# Altium schematic sheet MCU.SchDoc
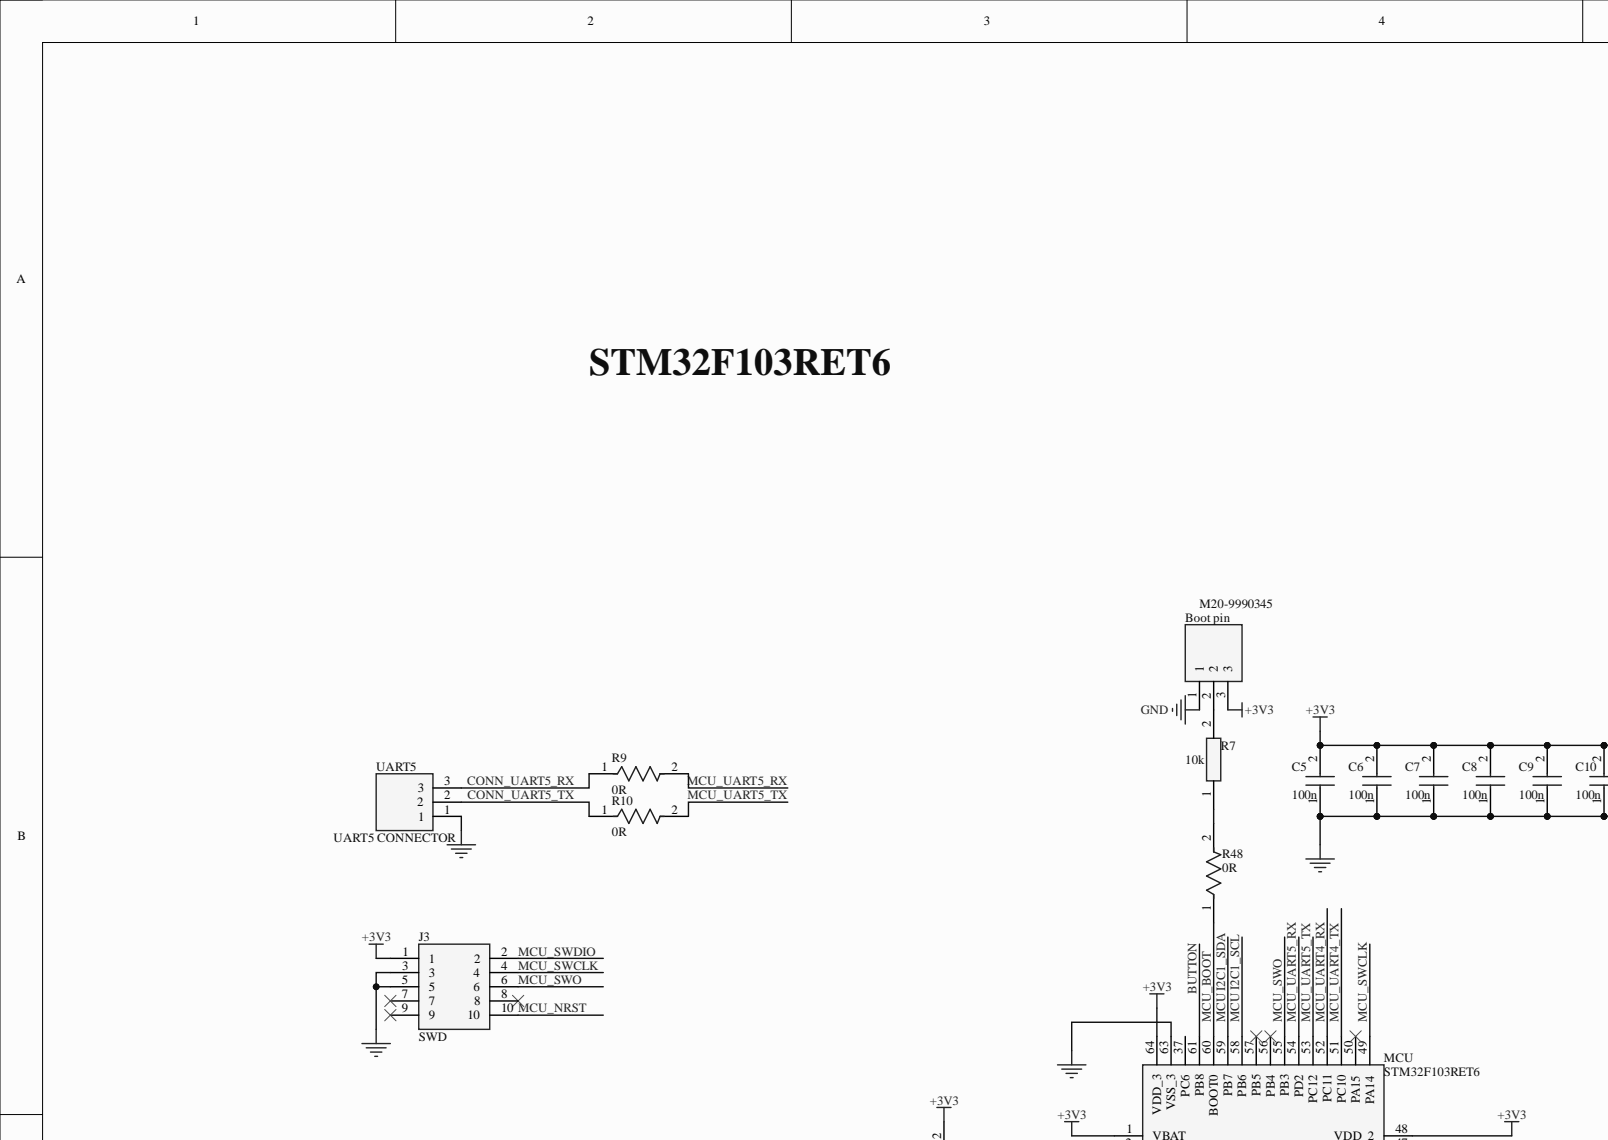
[diagram p0: MCU.SchDoc, top left]
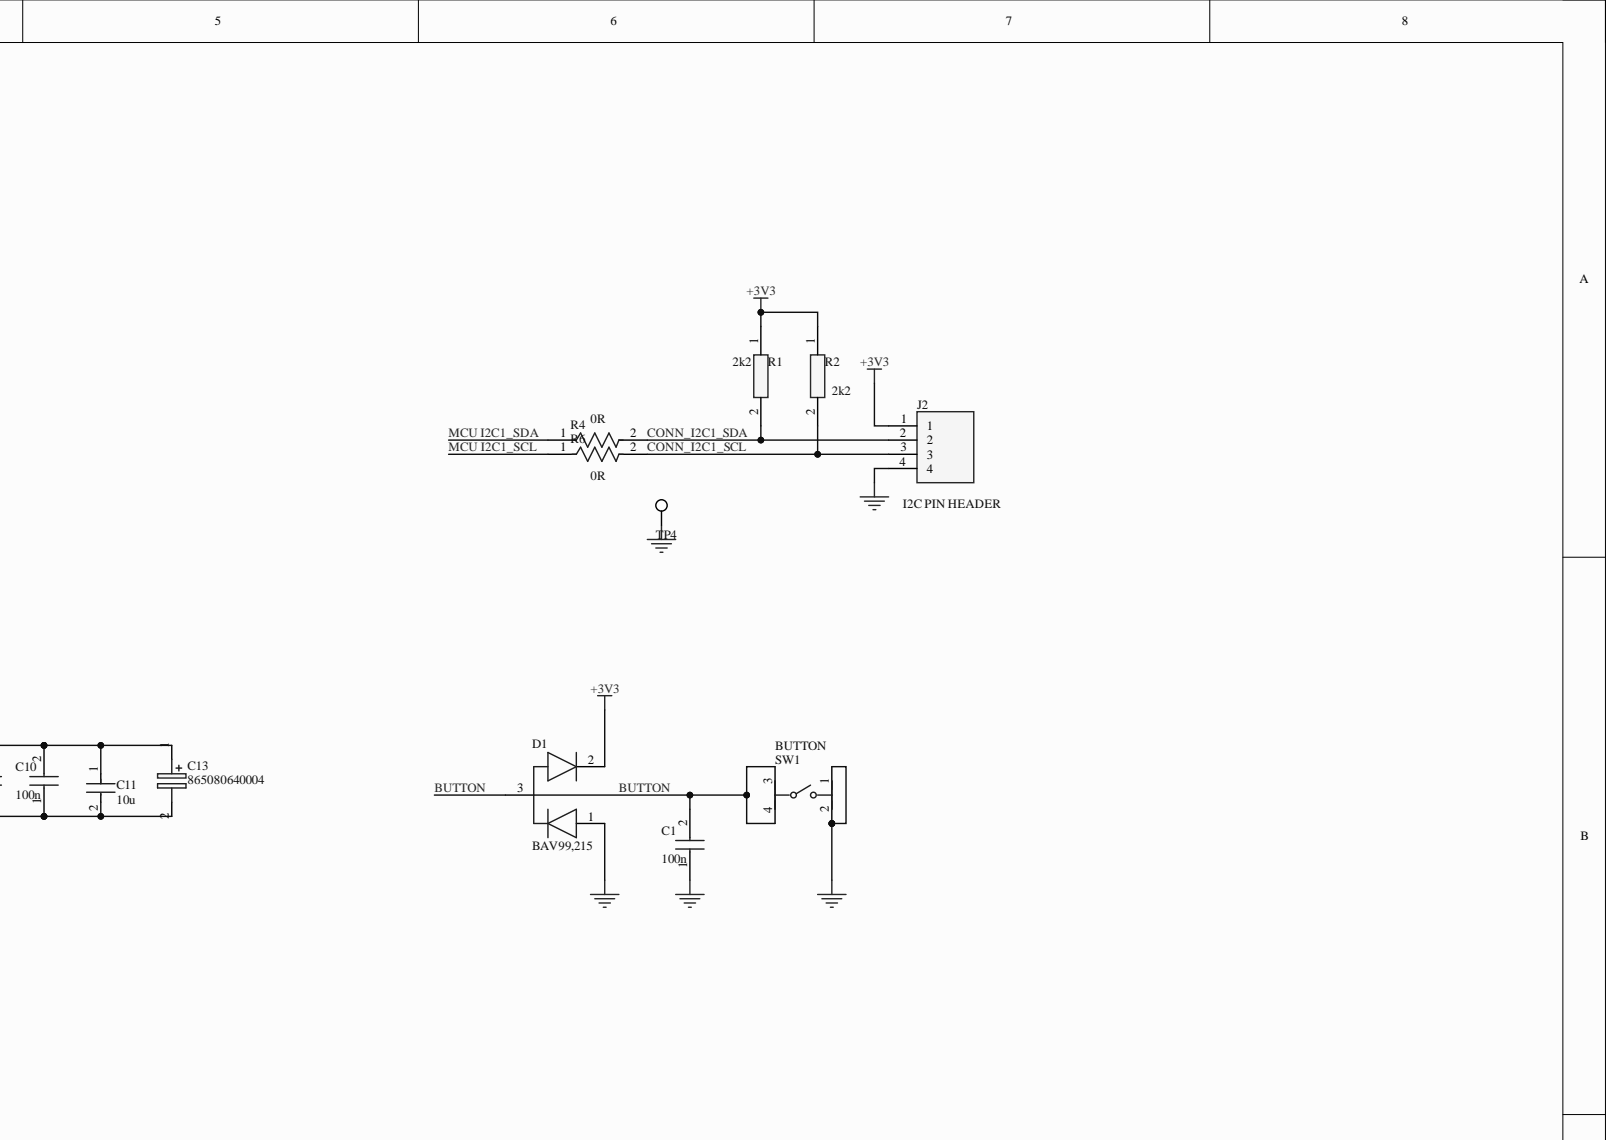
[diagram p1: MCU.SchDoc, top right]
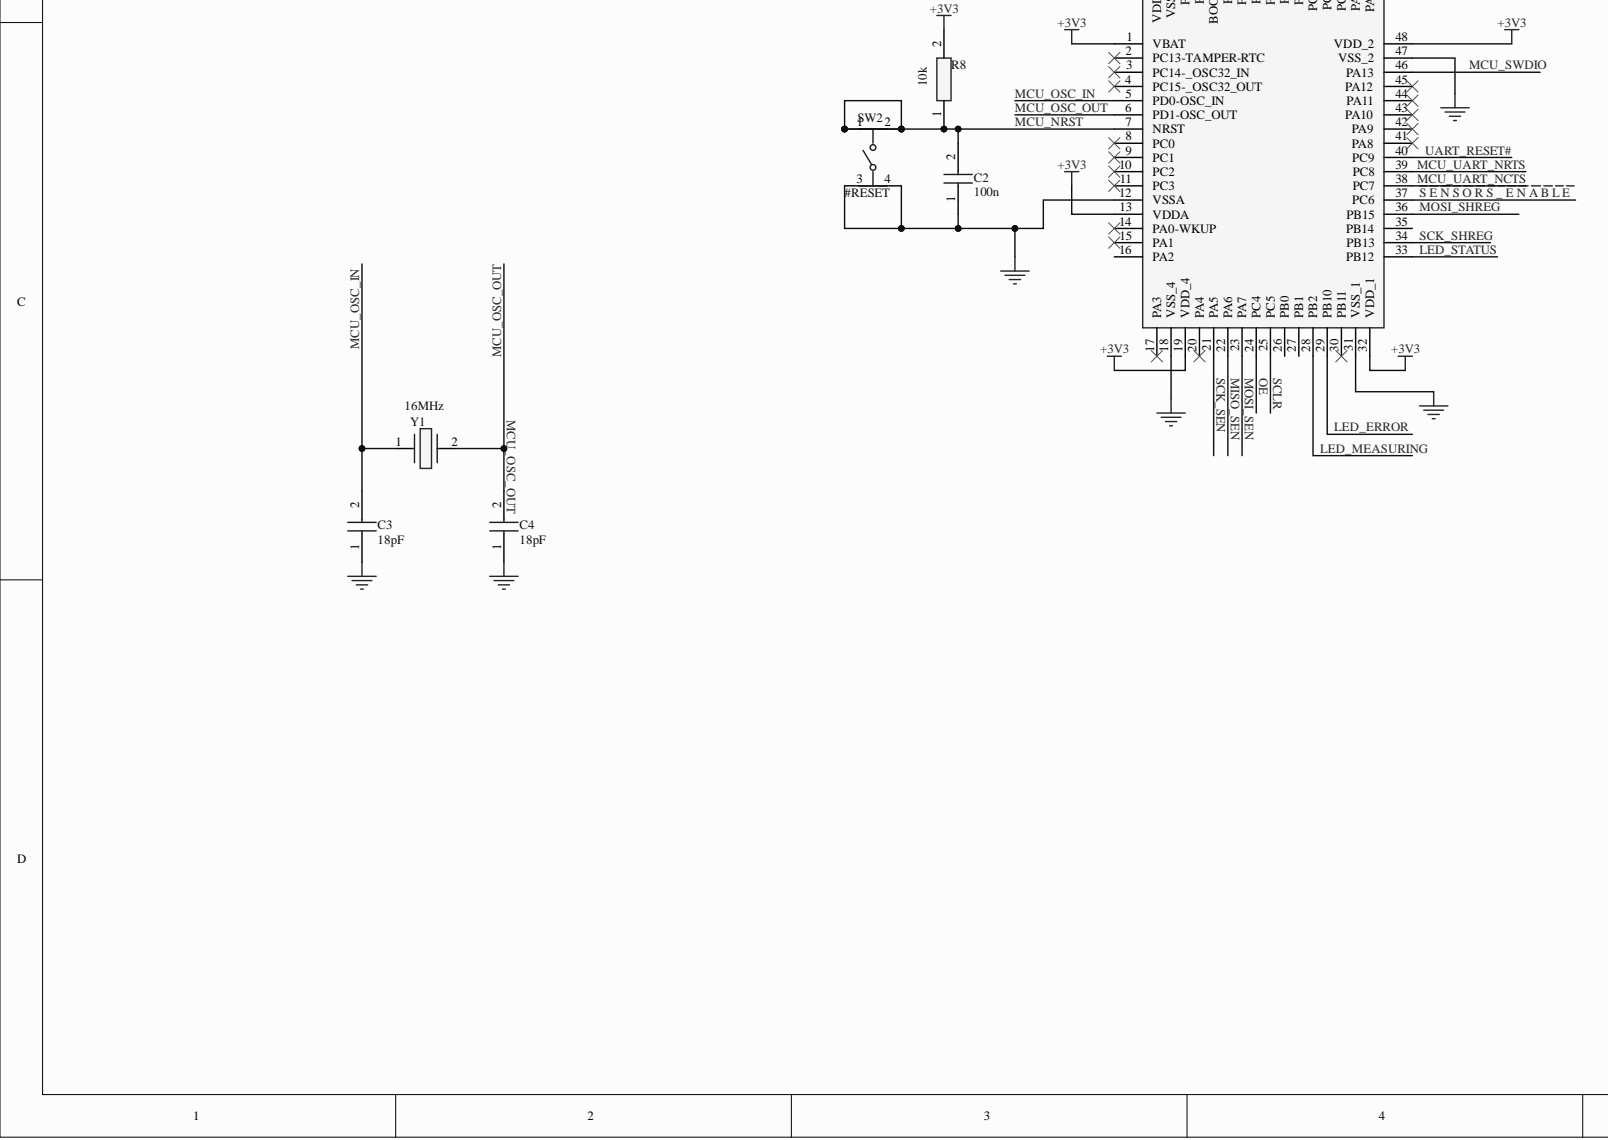
[diagram p2: MCU.SchDoc, bottom left]
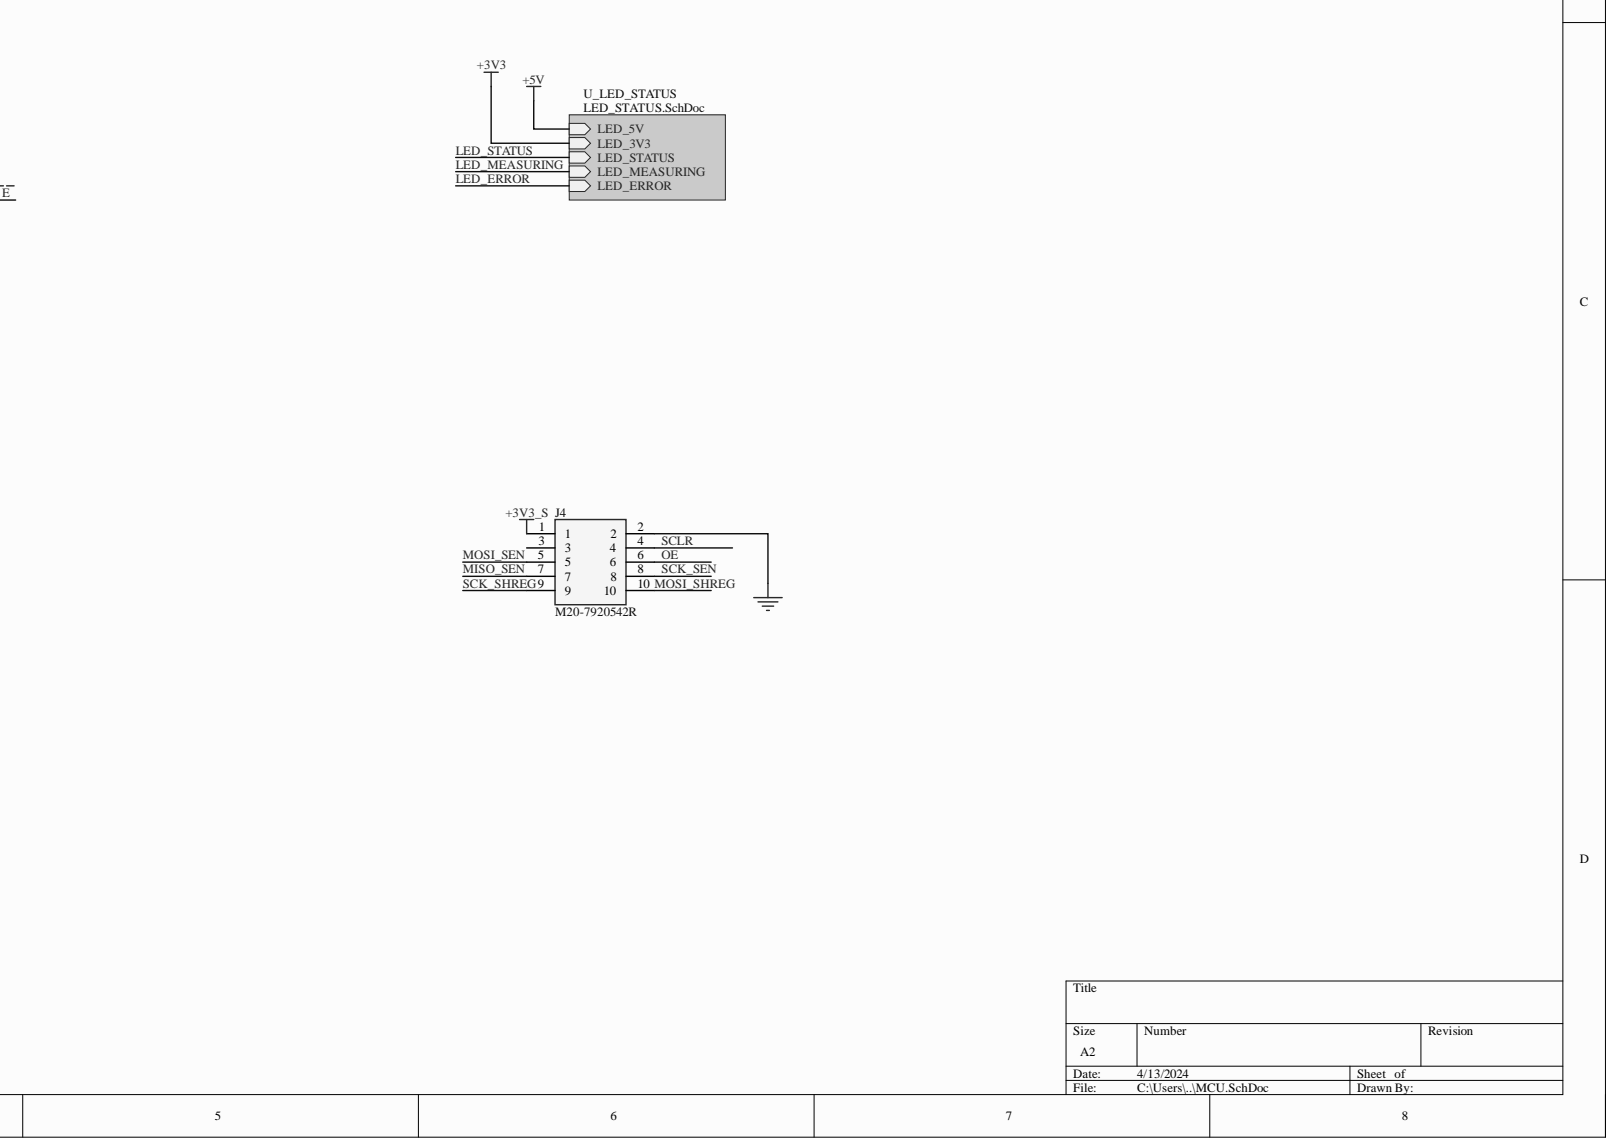
[diagram p3: MCU.SchDoc, bottom right]

## Parts
Boot_pin  M20-9990345  [HDRV3W64P0X254_1X3_762X254X864P]
C1  100n  [CAPC1608X95N]
C2  100n  [CAPC1608X90N]
C3  18pF  [C0603]
C4  18pF  [C0603]
C5  100n  [CAPC1608X95N]
C6  100n  [CAPC1608X95N]
C7  100n  [CAPC1608X95N]
C8  100n  [CAPC1608X95N]
C9  100n  [CAPC1608X95N]
C10  100n  [CAPC1608X95N]
C11  10u  [FP-GRM188-0_2-IPC_A]
C13  865080640004  [865080640004]
D1  BAV99,215  [BAV99215]
J2  I2C PIN HEADER  [HDRV4W90P0X254_1X4_994X249X560P]
J3  SWD  [HDRV10W64P254_2X5_1270X508X834P]
J4  M20-7920542R  [M207920542R]
MCU  STM32F103RET6  [QFP50P1200X1200X160-64N]
R1  2k2  [RESC1608X55N]
R2  2k2  [RESC1608X55N]
R4  0R  [FP-ERJ-3GEY0R00V-MFG]
R6  0R  [FP-ERJ-3GEY0R00V-MFG]
R7  10k  [RESC1608X55N]
R8  10k  [RESC1608X55N]
R9  0R  [FP-ERJ-3GEY0R00V-MFG]
R10  0R  [FP-ERJ-3GEY0R00V-MFG]
R48  0R  [FP-ERJ-3GEY0R00V-MFG]
SW1  BUTTON  [FP-PTS645SM43SMTR92LFS-MFG]
SW2  #RESET  [FP-PTS645SM43SMTR92LFS-MFG]
TP4  5019  [KSTN-5019_V]  (value not drawn)
UART5  UART5 CONNECTOR  [HDRV3W64P0X254_1X3_762X254X864P]
Y1  16MHz  [ABLS]

## Nets
+3V3: Boot_pin.3 C5.2 C6.2 C7.2 C8.2 C9.2 C10.2 C11.1 C13.1 D1.2 J2.1 J3.1 MCU.1(VBAT) MCU.13(VDDA) MCU.19(VDD_4) MCU.32(VDD_1) MCU.48(VDD_2) MCU.64(VDD_3) R1.1 R2.1 R8.2
+3V3_S: J4.1
GND: Boot_pin.1 C1.1 C2.1 C3.1 C4.1 C5.1 C6.1 C7.1 C8.1 C9.1 C10.1 C11.2 C13.2 D1.1 J2.4 J3.3 J3.5 J4.2 MCU.12(VSSA) MCU.18(VSS_4) MCU.31(VSS_1) MCU.47(VSS_2) MCU.63(VSS_3) SW1.1 SW1.2 SW2.3 SW2.4 TP4.1 UART5.1
BUTTON: C1.2 D1.3 MCU.61(PB8) SW1.3 SW1.4
CONN_I2C1_SCL: J2.3 R2.2 R6.2
CONN_I2C1_SDA: J2.2 R1.2 R4.2
CONN_UART5_RX: R9.1 UART5.3
CONN_UART5_TX: R10.1 UART5.2
LED_ERROR: MCU.29(PB10)
LED_MEASURING: MCU.28(PB2)
LED_STATUS: MCU.33(PB12)
MCU I2C1_SCL: MCU.58(PB6) R6.1
MCU I2C1_SDA: MCU.59(PB7) R4.1
MCU_BOOT: MCU.60(BOOT0) R48.1
MCU_NRST: C2.2 J3.10 MCU.7(NRST) R8.1 SW2.1 SW2.2
MCU_OSC_IN: C3.2 MCU.5(PD0-OSC_IN) Y1.1
MCU_OSC_OUT: C4.2 MCU.6(PD1-OSC_OUT) Y1.2
MCU_SWCLK: J3.4 MCU.49(PA14)
MCU_SWDIO: J3.2 MCU.46(PA13)
MCU_SWO: J3.6 MCU.55(PB3)
MCU_UART4_RX: MCU.52(PC11)
MCU_UART4_TX: MCU.51(PC10)
MCU_UART5_RX: MCU.54(PD2) R9.2
MCU_UART5_TX: MCU.53(PC12) R10.2
MCU_UART_NCTS: MCU.38(PC7)
MCU_UART_NRTS: MCU.39(PC8)
MISO_SEN: J4.7 MCU.22(PA6)
MOSI_SEN: J4.5 MCU.23(PA7)
MOSI_SHREG: J4.10 MCU.36(PB15)
OE: J4.6 MCU.24(PC4)
~{S} ~{E} ~{N} ~{S} ~{O} ~{R} ~{S} ~{_} ~{E} ~{N} ~{A} ~{B} ~{L} ~{E}: MCU.37
SCK_SEN: J4.8 MCU.21(PA5)
SCK_SHREG: J4.9 MCU.34(PB13)
SCLR: J4.4 MCU.25(PC5)
UART_RESET#: MCU.40(PC9)
NetBoot pin_2: Boot_pin.2 R7.2
NetJ3_7: J3.7
NetJ3_8: J3.8
NetJ3_9: J3.9
NetJ4_3: J4.3
NetMCU_2: MCU.2(PC13-TAMPER-RTC)
NetMCU_3: MCU.3(PC14-_OSC32_IN)
NetMCU_4: MCU.4(PC15-_OSC32_OUT)
NetMCU_8: MCU.8(PC0)
NetMCU_9: MCU.9(PC1)
NetMCU_10: MCU.10(PC2)
NetMCU_11: MCU.11(PC3)
NetMCU_14: MCU.14(PA0-WKUP)
NetMCU_15: MCU.15(PA1)
NetMCU_16: MCU.16(PA2)
NetMCU_17: MCU.17(PA3)
NetMCU_20: MCU.20(PA4)
NetMCU_26: MCU.26(PB0)
NetMCU_27: MCU.27(PB1)
NetMCU_30: MCU.30(PB11)
NetMCU_35: MCU.35(PB14)
NetMCU_37: MCU.37
NetMCU_41: MCU.41(PA8)
NetMCU_42: MCU.42(PA9)
NetMCU_43: MCU.43(PA10)
NetMCU_44: MCU.44(PA11)
NetMCU_45: MCU.45(PA12)
NetMCU_50: MCU.50(PA15)
NetMCU_56: MCU.56(PB4)
NetMCU_57: MCU.57(PB5)
NetR7_1: R7.1 R48.2
+5V:

## Library data of the parts
Boot_pin UART5 = M20-9990345: Connector; Manufacturer_Name: Harwin; Component Kind: Standard; Datasheet Link: https://cdn.harwin.com/pdfs/M20-999.pdf; Height: 8.64mm; Library Name: SamacSys.SchLib; Manufacturer_Part_Number: M20-9990345; Mouser Part Number: 855-M20-9990345; Mouser Price/Stock: https://www.mouser.co.uk/ProductDetail/Harwin/M20-9990345?qs=ulE8k0yEMYbl6ZPCtNdmpA%3D%3D
C1 C5-C10 = C0603C104J4RAC: Capacitor; Manufacturer_Name: KEMET; Arrow Part Number: C0603C104J4RAC; Arrow Price/Stock: https://www.arrow.com/en/products/c0603c104j4rac/kemet-corporation?region=nac; Component Kind: Standard; Datasheet Link: https://datasheet.datasheetarchive.com/originals/distributors/SFDatasheet-4/sf-00083930.pdf; Height: 0.95mm; Library Name: SamacSys.SchLib; Manufacturer_Part_Number: C0603C104J4RAC; Mouser Part Number: 80-C0603C104J4RAC; Mouser Price/Stock: https://www.mouser.co.uk/ProductDetail/KEMET/C0603C104J4RAC?qs=sykUljcfnH31MXmq5HGjkg%3D%3D
C2 = CL10A105KA8NFNC: Capacitor; Manufacturer_Name: SAMSUNG; Arrow Part Number: CL10A105KA8NFNC; Arrow Price/Stock: https://www.arrow.com/en/products/cl10a105ka8nfnc/samsung-electro-mechanics?region=nac; Component Kind: Standard; Datasheet Link: https://www.mouser.co.za/datasheet/2/585/MLCC-1837944.pdf; Height: 0.9mm; Manufacturer_Part_Number: CL10A105KA8NFNC; Mouser Part Number: 187-CL10A105KA8NFNC; Mouser Price/Stock: https://www.mouser.co.uk/ProductDetail/Samsung-Electro-Mechanics/CL10A105KA8NFNC?qs=%252B6g0mu59x7ItvV%2F7DV9Lig%3D%3D
C3 C4 = C0603C180K4HACTU: Capacitor; Manufacturer_Name: KEMET; Component Kind: Standard; Datasheet Link: https://content.kemet.com/datasheets/KEM_C1007_X8R_ULTRA_150C_SMD.pdf; Height: 0.87mm; Manufacturer_Part_Number: C0603C180K4HACTU; Mouser Part Number: 80-C0603C180K4HACTU; Mouser Price/Stock: https://www.mouser.com/Search/Refine.aspx?Keyword=80-C0603C180K4HACTU
C11 = CMP-2006-02814-2: Chip Multilayer Ceramic Capacitors for General Purpose, 0603, 10uF, X5R, 15%, 20%, 16V; Capacitance: 10uF; Case Code (Imperial): 0603; Case Code (Metric): 1608; Case/Package: 0603; Component Kind: Standard; Depth: 0.8mm; Dielectric: X5R; Height: 0.8mm; Lead Free: Lead Free; Length: 1.6mm; Library Name: Altium Content Vault; Max Operating Temperature: 85°C; Min Operating Temperature: -55°C; Mount: Surface Mount; Package Quantity: 4000; Packaging: Tape and Reel; REACH SVHC: No SVHC; Thickness: 0.031inch; Tolerance: 20%; Voltage: 16V; Voltage Rating: 16V; Voltage Rating (DC): 16V; Width: 0.031inch
C13 = 865080640004: Capacitor Polarised; Manufacturer_Name: Wurth Elektronik; Component Kind: Standard; Datasheet Link: https://www.we-online.de/katalog/datasheet/865080640004.pdf; Height: 5.5mm; Library Name: SamacSys.SchLib; Manufacturer_Part_Number: 865080640004; Mouser Part Number: 710-865080640004; Mouser Price/Stock: https://www.mouser.co.uk/ProductDetail/Wurth-Elektronik/865080640004?qs=0KOYDY2FL2%2FjY4kqBxIvAg%3D%3D
D1 = BAV99,215: Diode; Manufacturer_Name: Nexperia; Arrow Part Number: BAV99,215; Arrow Price/Stock: https://www.arrow.com/en/products/bav99215/nexperia?region=nac; Component Kind: Standard; Datasheet Link: https://assets.nexperia.com/documents/data-sheet/BAV99_SER.pdf; Height: 1.1mm; Manufacturer_Part_Number: BAV99,215; Mouser Part Number: 771-BAV99-T/R; Mouser Price/Stock: https://www.mouser.co.uk/ProductDetail/Nexperia/BAV99215?qs=me8TqzrmIYWbN0bCKV3n5w%3D%3D
J2 = 90120-0764: Connector; Manufacturer_Name: Molex; Component Kind: Standard; Datasheet Link: https://www.molex.com/webdocs/datasheets/pdf/en-us/0901200764_PCB_HEADERS.pdf; Height: 5.6mm; Library Name: SamacSys.SchLib; Manufacturer_Part_Number: 90120-0764; Mouser Part Number: 538-90120-0764; Mouser Price/Stock: https://www.mouser.co.uk/ProductDetail/Molex/90120-0764?qs=QSnLD11VNirsMTdnHEPG3Q%3D%3D
J3 = M20-9760542: Connector; Manufacturer_Name: Harwin; Component Kind: Standard; Datasheet Link: https://cdn.harwin.com/pdfs/M20-976.pdf; Height: 8.34mm; Library Name: SamacSys.SchLib; Manufacturer_Part_Number: M20-9760542; Mouser Part Number: 855-M20-9760542; Mouser Price/Stock: https://www.mouser.co.uk/ProductDetail/Harwin/M20-9760542?qs=WS5Jv%252B%252Bx1qWXnFWOyfr5DQ%3D%3D
J4 = M20-7920542R: Connector; Manufacturer_Name: Harwin; Component Kind: Standard; Datasheet Link: https://cdn.harwin.com/pdfs/M20-792R.pdf; Height: 5mm; Library Name: SamacSys.SchLib; Manufacturer_Part_Number: M20-7920542R; Mouser Part Number: 855-M20-7920542R; Mouser Price/Stock: https://www.mouser.co.uk/ProductDetail/Harwin/M20-7920542R?qs=k41KVqW3ymqj80AqJ%2FxUlA%3D%3D
MCU = STM32F103RET6: Integrated Circuit; Manufacturer_Name: STMicroelectronics; Arrow Part Number: STM32F103RET6; Arrow Price/Stock: https://www.arrow.com/en/products/stm32f103ret6/stmicroelectronics?region=nac; Component Kind: Standard; Datasheet Link: http://www.st.com/st-web-ui/static/active/en/resource/technical/document/datasheet/CD00191185.pdf; Height: 1.6mm; Manufacturer_Part_Number: STM32F103RET6; Mouser Part Number: 511-STM32F103RET6; Mouser Price/Stock: https://www.mouser.co.uk/ProductDetail/STMicroelectronics/STM32F103RET6?qs=tZXS5FmuAdnGL83bu1Xcew%3D%3D
R1 R2 R7 R8 = RK73B1JTTD103J: Resistor; Manufacturer_Name: KOA Speer; Arrow Part Number: RK73B1JTTD103J; Arrow Price/Stock: https://www.arrow.com/en/products/rk73b1jttd103j/koa-speer-electronics?region=asia; Component Kind: Standard; Datasheet Link: http://www.koaspeer.com/catimages/Products/RK73-RT/RK73-RT.pdf; Height: 0.55mm; Manufacturer_Part_Number: RK73B1JTTD103J; Mouser Part Number: 660-RK73B1JTTD103J; Mouser Price/Stock: https://www.mouser.co.uk/ProductDetail/KOA-Speer/RK73B1JTTD103J?qs=hmtrJ2UjXcBKRTcwO9LloQ%3D%3D
R4 R6 R9 R10 R48 = CMP-2000-00700-2: RES SMD 0 OHM JUMPER 1/10W 0603; Manufacturer: Panasonic; Manufacturer Part Number: ERJ-3GEY0R00V; Automotive Grade: Grade 0; Power Rating: 0.1W; Resistance: 0Ω; Temperature Coefficient: -100/+600ppm/°C; Tolerance: 5%; Value: 0Ω; Voltage Rating: 75V; Automotive: Yes; Category: Res; Component Kind: Standard; ComponentLink2Description: Datasheet; ComponentLink2URL: https://industrial.panasonic.com/cdbs/www-data/pdf/RDA0000/AOA0000C301.pdf; Composition: Thick Film; Device Class L1: Passive Components; Device Class L2: Resistors; Device Class L3: Chip SMD Resistors; Digikey Description: RES SMD 0 OHM JUMPER 1/10W 0603; Footprint Url: https://industrial.panasonic.com/cdbs/www-data/pdf/RDA0000/DMA0000COL17.pdf; Height: 0.55mm; Ipc Land Pattern Name: RESC16080X45; Lead Free: Yes; Library Name: Altium Content Vault; Mouser Description: Resistor, Chip Thick Film, 0 Ohm, 0.1w, Resistor, Chip Thick Film, 0 Ohm, 0.1w, 5%; Octopart: https://octopart.com/erj-3gey0r00v-panasonic-55389238; Package: 0603; RoHS: TRUE; Series: ERJ; Temperature: -55°C to +155°C; Temperature Range High: +155°C; Temperature Range Low: -55°C
SW1 SW2 = CMP-2000-05160-2: SWITCH TACTILE SPST-NO 0.05A 12V; Actuator Length: 0.85mm; Actuator Material: Nylon; Actuator Type: Standard; Contact Resistance: 100mR; Current Rating: 50mA; Illumination Type: Non-Illuminated; Mechanical Life: 100000Cycles; Operating Force: 160gf; Switch Function: Off-Mom; Throw Configuration: SPST; Case/Package: SMD/SMT; Color: Black; Component Kind: Standard; Contact Material: Silver; Contact Plating: Silver; Depth: 9mm; Lead Free: Lead Free; Length: 6mm; Library Name: Altium Content Vault; Max Current Rating: 50mA; Max Operating Temperature: 60°C; Max Voltage Rating (DC): 12V; Min Operating Temperature: -20°C; Mount: Surface Mount; Orientation: Straight; Package Quantity: 1; Packaging: Tape and Reel; REACH SVHC: No SVHC; Termination: Gull Wing; Voltage Rating (DC): 12V
TP4 = CMP-1672-00008-1: Test Point, 1 Position SMD, RoHS, Tape and Reel; Component Kind: Standard; ComponentLink1Description: Manufacturer URL; ComponentLink1URL: http://www.keyelco.com/; ComponentLink2Description: Datasheet; ComponentLink2URL: http://www.keyelco.com/product-pdf.cfm?p=1357; Contact Plating: Silver; DatasheetVersion: 03/2014; Library Name: Altium Content Vault; Mount: Surface Mount; Mounting Technology: Surface Mount; Package Quantity: 1000; PackageDescription: 1-Pin Surface Mount Device, Body 3.81 x 2.03 mm; PackageReference: 5019; Packaging: Tape and Reel; Pins: 1; RoHSCompliant: True
Y1 = ABLS-16.000MHZ-B2-T: Crystal or Oscillator; Manufacturer_Name: ABRACON; Arrow Part Number: ABLS-16.000MHZ-B2-T; Arrow Price/Stock: https://www.arrow.com/en/products/abls-16.000mhz-b2-t/abracon?region=nac; Component Kind: Standard; Datasheet Link: https://abracon.com/Resonators/ABLS.pdf; Library Name: SamacSys.SchLib; Manufacturer_Part_Number: ABLS-16.000MHZ-B2-T; Mouser Part Number: 815-ABLS-16-B2; Mouser Price/Stock: https://www.mouser.co.uk/ProductDetail/ABRACON/ABLS-16000MHZ-B2-T?qs=m%252BUhWDcpCfbvl4COQ0ty%2FA%3D%3D
